# Altium schematic sheet mcu.SchDoc
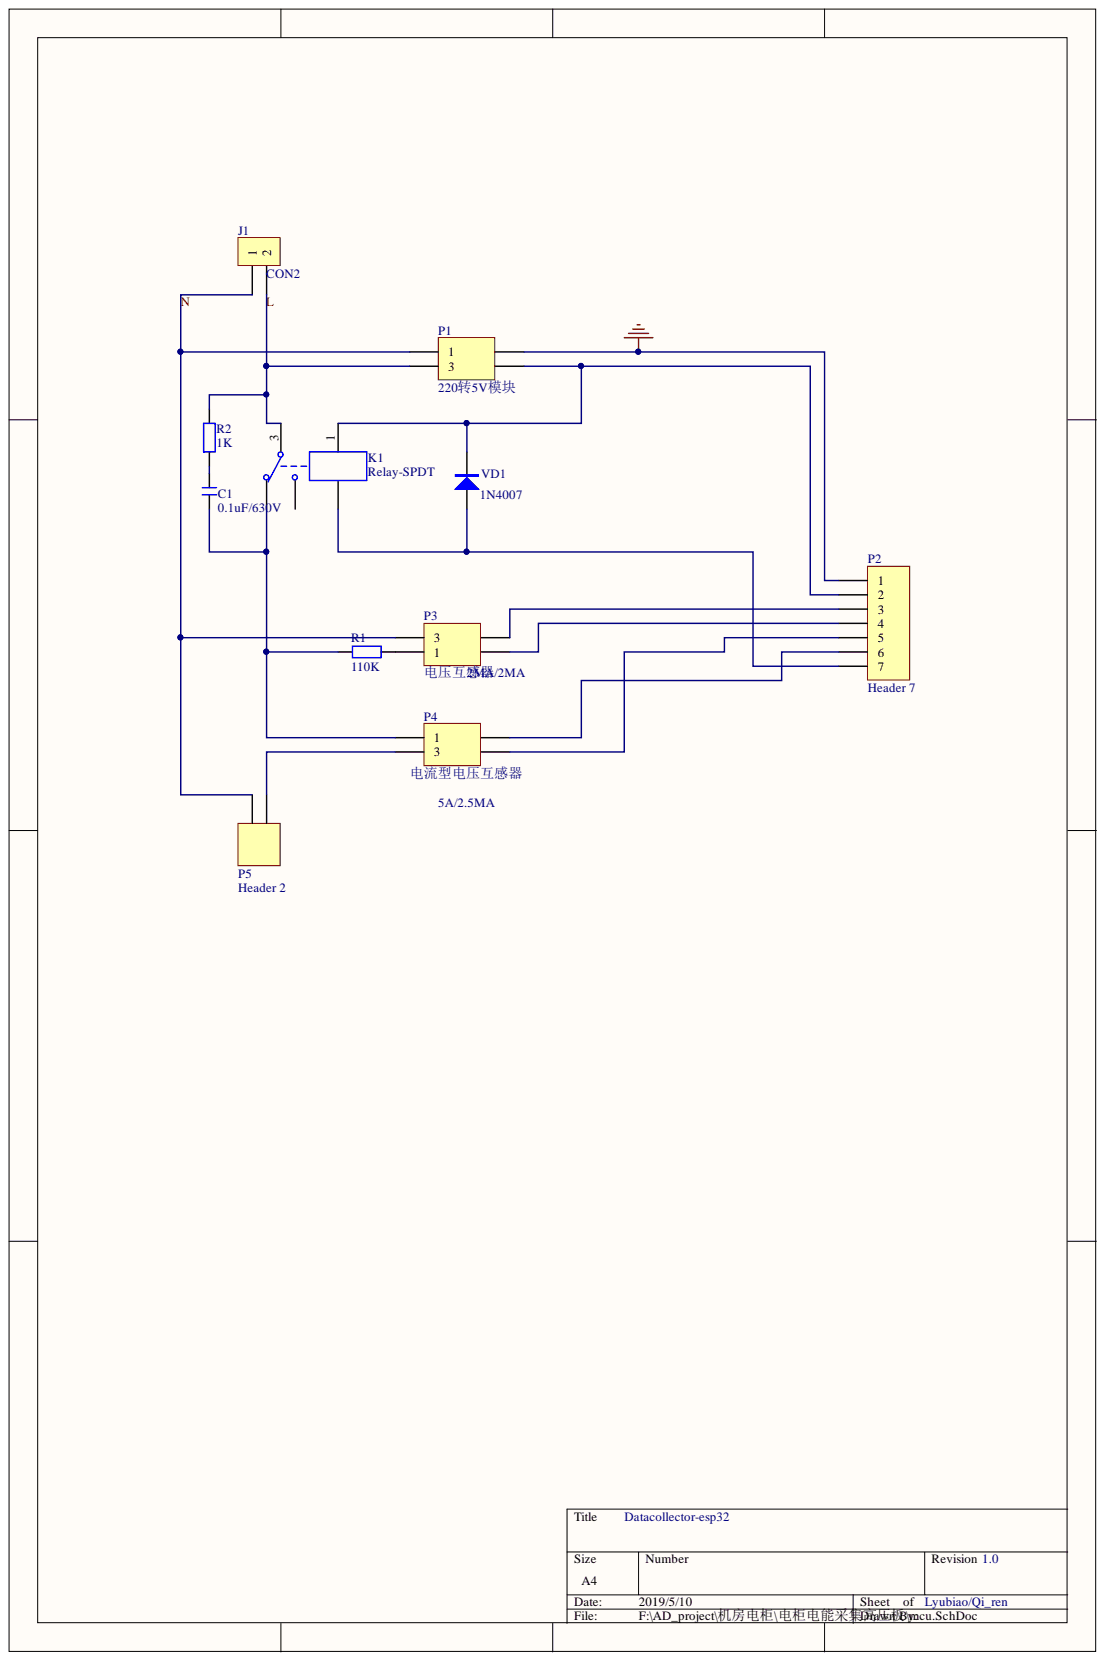
[diagram p0: mcu.SchDoc]

## Parts
C1  0.1uF/630V  [CBB 10*12*8]
J1  CON2  [NL]
K1  Relay-SPDT  [SRD-C_B]
P1  220转5V模块  [AC220V-DC5V]
P2  Header 7  [XH2.54-7P]
P3  电压互感器  [ZMPT107]
P4  电流型电压互感器  [ZDCT-03]
P5  Header 2  [NL]
R1  110K  [R 2512]
R2  1K  [RS 2W-V6]
VD1  1N4007  [SMA]

## Nets
GND: P1.2 P2.1
L: J1.2 K1.3 P1.3 R2.1
N: J1.1 P1.1 P3.3 P5.2
NetC1_1: C1.1 R2.2
NetC1_2: C1.2 K1.4 P4.1 R1.1
NetK1_1: K1.1 P1.4 P2.2 VD1.2
NetK1_2: K1.2 P2.7 VD1.1
NetP2_3: P2.3 P3.4
NetP2_4: P2.4 P3.2
NetP2_5: P2.5 P4.4
NetP2_6: P2.6 P4.2
NetP3_1: P3.1 R1.2
NetP4_3: P4.3 P5.1

Not connected: K1 5

## Library data of the parts
C1 = C-CBB: CBB电容; 版权归属: 黎明电子style; BOM名称: CBB电容; Component Kind: Standard; Library Name: 电阻-电容-电感.SchLib; 学习资源: https://lmdzstyle.taobao.com/
J1 = CON2: Connector; Component Kind: Standard
K1 = Relay-SPDT: Single-Pole Dual-Throw Relay; Component Kind: Standard; LatestRevisionDate: 17-Jul-2002; LatestRevisionNote: Re-released for DXP Platform.; Library Name: Miscellaneous Devices.IntLib; PackageReference: MODULE5B; Published: 24-Mar-1999; Simulation: SPDTRELAY; Publisher: Altium Limited
P1 P3 P4 = Header 2X2: Header, 2-Pin, Dual row; Component Kind: Standard; LatestRevisionDate: 17-Jul-2002; LatestRevisionNote: Re-released for DXP Platform.; Library Name: Miscellaneous Connectors.IntLib; Signal Integrity: Connector; Publisher: Altium Limited
P2 = Header 7: Header, 7-Pin; Component Kind: Standard; LatestRevisionDate: 17-Jul-2002; LatestRevisionNote: Re-released for DXP Platform.; Library Name: Miscellaneous Connectors.IntLib; Signal Integrity: Connector; Publisher: Altium Limited
P5 = Header 2: Header, 2-Pin; Component Kind: Standard; LatestRevisionDate: 17-Jul-2002; LatestRevisionNote: Re-released for DXP Platform.; Library Name: Miscellaneous Connectors.IntLib; Signal Integrity: Connector; Publisher: Altium Limited
R1 = R: 贴片电阻; 版权归属: 黎明电子style; BOM名称: 贴片电阻; Component Kind: Standard; Library Name: 电阻-电容-电感.SchLib; 学习资源: https://lmdzstyle.taobao.com/
R2 = R-CRR: 直插色环电阻; BOM名称: 色环电阻; 版权归属: 黎明电子style; Component Kind: Standard; Library Name: 电阻-电容-电感.SchLib; 学习资源: https://lmdzstyle.taobao.com/
VD1 = 1N4007: 整流二极管; 版权归属: 黎明电子style; BOM名称: 整流二极管; Component Kind: Standard; Library Name: 二极管-整流桥.SchLib; 学习资源: https://lmdzstyle.taobao.com/
